# Altium schematic sheet Sheet1.SchDoc
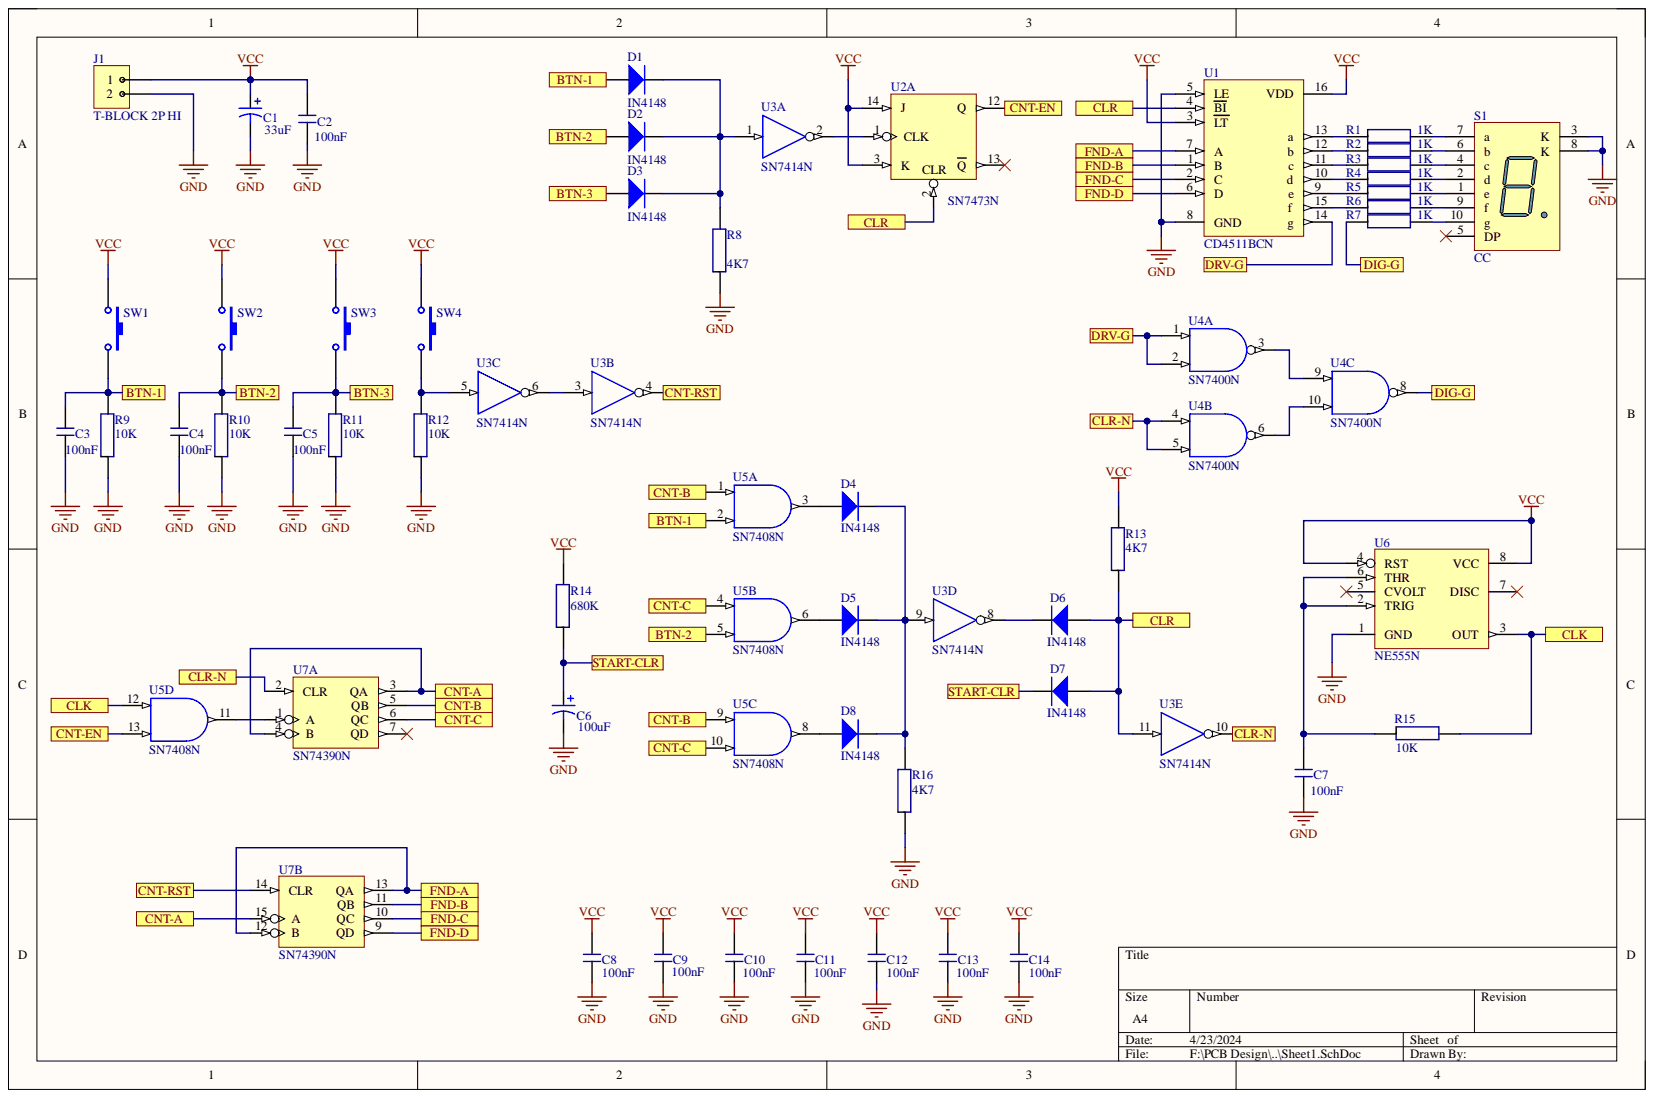
[diagram p0: Sheet1.SchDoc]

## Parts
C1  value 33uF  [cap 6mm]  (hidden comment: Capasitor Polar)
C2  value 100nF  [0805]  (hidden comment: Capasitor Non Polar)
C3  value 100nF  [0805]  (hidden comment: Capasitor Non Polar)
C4  value 100nF  [0805]  (hidden comment: Capasitor Non Polar)
C5  value 100nF  [0805]  (hidden comment: Capasitor Non Polar)
C6  value 100uF  [Elco Axial 1 - B]  (hidden comment: Capasitor Polar)
C7  value 100nF  [Cap MKM]  (hidden comment: Capasitor Non Polar)
C8  value 100nF  [Cap MKM]  (hidden comment: Capasitor Non Polar)
C9  value 100nF  [Cap MKM]  (hidden comment: Capasitor Non Polar)
C10  value 100nF  [Cap MKM]  (hidden comment: Capasitor Non Polar)
C11  value 100nF  [Cap MKM]  (hidden comment: Capasitor Non Polar)
C12  value 100nF  [Cap MKM]  (hidden comment: Capasitor Non Polar)
C13  value 100nF  [Cap MKM]  (hidden comment: Capasitor Non Polar)
C14  value 100nF  [Cap MKM]  (hidden comment: Capasitor Non Polar)
D1  IN4148  [LL34]
D2  IN4148  [LL34]
D3  IN4148  [LL34]
D4  IN4148  [LL34]
D5  IN4148  [LL34]
D6  IN4148  [LL34]
D7  IN4148  [LL34]
D8  IN4148  [LL34]
J1  T-BLOCK 2P HI  [T-BLOCK 2P HL]
R1  value 1K  [0805]  (hidden comment: Resistor)
R2  value 1K  [0805]  (hidden comment: Resistor)
R3  value 1K  [0805]  (hidden comment: Resistor)
R4  value 1K  [0805]  (hidden comment: Resistor)
R5  value 1K  [0805]  (hidden comment: Resistor)
R6  value 1K  [0805]  (hidden comment: Resistor)
R7  value 1K  [0805]  (hidden comment: Resistor)
R8  value 4K7  [0805]  (hidden comment: Resistor)
R9  value 10K  [0805]  (hidden comment: Resistor)
R10  value 10K  [0805]  (hidden comment: Resistor)
R11  value 10K  [0805]  (hidden comment: Resistor)
R12  value 10K  [0805]  (hidden comment: Resistor)
R13  value 4K7  [0805]  (hidden comment: Resistor)
R14  value 680K  [Axial 0.4]  (hidden comment: Resistor)
R15  value 10K  [0805]  (hidden comment: Resistor)
R16  value 4K7  [0805]  (hidden comment: Resistor)
S1  CC  [S7 0.56]
SW1  Tactile switch 2 P  [tactileSW2p]  (value not drawn)
SW2  Tactile switch 2 P  [tactileSW2p]  (value not drawn)
SW3  Tactile switch 2 P  [tactileSW2p]  (value not drawn)
SW4  Tactile switch 2 P  [tactileSW2p]  (value not drawn)
U1  CD4511BCN  [N16E]
U2  SN7473N  [N014C]  (part A)
U3  SN7414N  [N014]  (parts A,B,C,D,E)
U4  SN7400N  [N014]  (parts A,B,C)
U5  SN7408N  [N014]  (parts A,B,C,D)
U6  NE555N  [DIP8]
U7  SN74390N  [N016]  (parts A,B)

## Ports
BTN-1, BTN-2, BTN-3, CLK, CLR, CLR-N, CNT-A, CNT-B, CNT-C, CNT-EN, CNT-RST, DIG-G, DRV-G, FND-A, FND-B, FND-C, FND-D, START-CLR

## Nets
GND: C1.2 C2.2 C3.2 C4.2 C5.2 C6.2 C7.2 C8.2 C9.2 C10.2 C11.2 C12.2 C13.2 C14.2 J1.2 R8.2 R9.2 R10.2 R11.2 R12.2 R16.2 S1.3(K) S1.8(K) U1.5(LE) U1.8(GND) U2.11 U3.7 U4.7 U5.7 U6.1(GND) U7.8
VCC: C1.1 C2.1 C8.1 C9.1 C10.1 C11.1 C12.1 C13.1 C14.1 J1.1 R13.1 R14.1 SW1.1 SW2.1 SW3.1 SW4.1 U1.3(LT) U1.16(VDD) U2.3(K) U2.4 U2.14(J) U3.14 U4.14 U5.14 U6.4(RST) U6.8(VCC) U7.16
BTN-1: C3.1 D1.1 R9.1 SW1.2 U5.2 ; port BTN-1
BTN-2: C4.1 D2.1 R10.1 SW2.2 U5.5 ; port BTN-2
BTN-3: C5.1 D3.1 R11.1 SW3.2 ; port BTN-3
CLK: R15.1 U5.12 U6.3(OUT) ; port CLK
CLR: D6.1 D7.1 R13.2 U1.4(BI) U2.2 U3.11 ; port CLR
CLR-N: U3.10 U4.4 U4.5 U7.2(CLR) ; port CLR-N
CNT-A: U7.3(QA) U7.4(B) U7.15(A) ; port CNT-A
CNT-B: U5.1 U5.9 U7.5(QB) ; port CNT-B
CNT-C: U5.4 U5.10 U7.6(QC) ; port CNT-C
CNT-EN: U2.12(Q) U5.13 ; port CNT-EN
CNT-RST: U3.4 U7.14(CLR) ; port CNT-RST
DIG-G: R7.2 U4.8 ; port DIG-G
DRV-G: U1.14(g) U4.1 U4.2 ; port DRV-G
FND-A: U1.7(A) U7.12(B) U7.13(QA) ; port FND-A
FND-B: U1.1(B) U7.11(QB) ; port FND-B
FND-C: U1.2(C) U7.10(QC) ; port FND-C
FND-D: U1.6(D) U7.9(QD) ; port FND-D
START-CLR: C6.1 D7.2 R14.2 ; port START-CLR
NetC7_1: C7.1 R15.2 U6.2(TRIG) U6.6(THR)
NetD1_2: D1.2 D2.2 D3.2 R8.1 U3.1
NetD4_1: D4.1 U5.3
NetD4_2: D4.2 D5.2 D8.2 R16.1 U3.9
NetD5_1: D5.1 U5.6
NetD6_2: D6.2 U3.8
NetD8_1: D8.1 U5.8
NetR1_1: R1.1 S1.7(a)
NetR1_2: R1.2 U1.13(a)
NetR2_1: R2.1 S1.6(b)
NetR2_2: R2.2 U1.12(b)
NetR3_1: R3.1 S1.4(c)
NetR3_2: R3.2 U1.11(c)
NetR4_1: R4.1 S1.2(d)
NetR4_2: R4.2 U1.10(d)
NetR5_1: R5.1 S1.1(e)
NetR5_2: R5.2 U1.9(e)
NetR6_1: R6.1 S1.9(f)
NetR6_2: R6.2 U1.15(f)
NetR7_1: R7.1 S1.10(g)
NetR12_1: R12.1 SW4.2 U3.5
NetU2_1: U2.1(CLK) U3.2
NetU3_3: U3.3 U3.6
NetU4_3: U4.3 U4.9
NetU4_6: U4.6 U4.10
NetU5_11: U5.11 U7.1(A)

Not connected: S1 5(DP); U2 13(Q); U6 5(CVOLT) 7(DISC); U7 7(QD)

## Library data of the parts
D1-D8 = Diode IN40XX: Value: IN400X; Component Kind: Standard; Library Name: faridLib.IntLib
S1 = Display S7 CC: Component Kind: Standard; Library Name: faridLib.IntLib
U1 = CD4511BCN: BCD-to-7 Segment Latch/Decoder/Driver; Component Kind: Standard; ComponentLink1Description: Manufacturer Link; ComponentLink1URL: http://www.fairchildsemi.com/; ComponentLink2Description: Datasheet; ComponentLink2URL: http://www.fairchildsemi.com/ds/CD%2FCD4511BC.pdf; DatasheetVersion: Jan-1999; LatestRevisionDate: 04-Mar-2005; LatestRevisionNote: Stylized 3D Model Added.; Library Name: FSC Interface Display Driver.IntLib; PackageDescription: DIP, 16-Pin, Row Spacing 7.62 mm, Pitch 2.54 mm; PackageReference: N16E; PackageVersion: 2000; PCB3D: N16E; Published: 17-Jul-2002; Simulation: 4511; Publisher: Altium Limited
U2 = SN7473N: Dual J-K Flip-Flop with Clear; ComponentAvailability: Obsolete; Component Kind: Standard; ComponentLink1Description: Manufacturer Link; ComponentLink1URL: http://www.ti.com/; ComponentLink2Description: Datasheet; ComponentLink2URL: http://www-s.ti.com/sc/ds/sn7473.pdf; DatasheetVersion: Mar-1988; LatestRevisionDate: 04-Mar-2005; LatestRevisionNote: Stylized 3D Model Added.; Library Name: TI Logic Flip-Flop.IntLib; PackageDescription: DIP; 14 Leads; Row Spacing 7.62 mm; Pitch 2.54 mm; PackageReference: N014C; PackageVersion: Oct-1995; PCB3D: N014; Published: 8-Jun-2000; Signal Integrity: SN7473N; Publisher: Altium Limited
U3 = SN7404N: Hex Inverter; Component Kind: Standard; ComponentLink1Description: Manufacturer Link; ComponentLink1URL: http://www.ti.com/; ComponentLink2Description: Datasheet; ComponentLink2URL: http://www-s.ti.com/sc/ds/sn5404.pdf; ComponentLink3Description: Packaging Specification; ComponentLink3URL: http://focus.ti.com/lit/ml/mpdi002c/mpdi002c.pdf; DatasheetVersion: Mar-1988; LatestRevisionDate: 04-Mar-2005; LatestRevisionNote: Stylized 3D Model Added.; Library Name: TI Logic Gate 1.IntLib; PackageDescription: DIP; 14 Leads; Row Spacing 7.62 mm; Pitch 2.54 mm; PackageReference: N014; PackageVersion: Oct-1995; PCB3D: N014; Published: 8-Jun-2000; Signal Integrity: SN7404N; Publisher: Altium Limited
U4 = SN7400N: Quadruple 2-Input Positive-NAND Gate; Component Kind: Standard; ComponentLink1Description: Manufacturer Link; ComponentLink1URL: http://www.ti.com/; ComponentLink2Description: Datasheet; ComponentLink2URL: http://www-s.ti.com/sc/ds/sn5400.pdf; ComponentLink3Description: Packaging Specification; ComponentLink3URL: http://focus.ti.com/lit/ml/mpdi002c/mpdi002c.pdf; DatasheetVersion: Mar-1988; LatestRevisionDate: 04-Mar-2005; LatestRevisionNote: Stylized 3D Model Added.; Library Name: TI Logic Gate 1.IntLib; PackageDescription: DIP; 14 Leads; Row Spacing 7.62 mm; Pitch 2.54 mm; PackageReference: N014; PackageVersion: Oct-1995; PCB3D: N014; Published: 8-Jun-2000; Signal Integrity: SN7400N; Publisher: Altium Limited
U5 = SN7408N: Quadruple 2-Input Positive-AND Gate; Component Kind: Standard; ComponentLink1Description: Manufacturer Link; ComponentLink1URL: http://www.ti.com/; ComponentLink2Description: Datasheet; ComponentLink2URL: http://www-s.ti.com/sc/ds/sn54ls08.pdf; ComponentLink3Description: Packaging Specification; ComponentLink3URL: http://focus.ti.com/lit/ml/mpdi002c/mpdi002c.pdf; DatasheetVersion: Mar-1988; LatestRevisionDate: 04-Mar-2005; LatestRevisionNote: Stylized 3D Model Added.; Library Name: TI Logic Gate 1.IntLib; PackageDescription: DIP; 14 Leads; Row Spacing 7.62 mm; Pitch 2.54 mm; PackageReference: N014; PackageVersion: Oct-1995; PCB3D: N014; Published: 8-Jun-2000; Signal Integrity: SN7408N; Publisher: Altium Limited
U6 = NE555N: General-Purpose Single Bipolar Timer; Code_JEDEC: MO-001-AN; Component Kind: Standard; ComponentLink1Description: Manufacturer Link; ComponentLink1URL: http://www.st.com/; ComponentLink2Description: Datasheet; ComponentLink2URL: http://www.st.com/stonline/products/literature/ds/2182/ne555.pdf; DatasheetVersion: Jul-1998; LatestRevisionDate: 06-Jun-2005; LatestRevisionNote: Stylized 3D Model Added.; Library Name: ST Analog Timer Circuit.IntLib; PackageDescription: DIP; 8 Leads; Row Spacing 7.62 mm; Pitch 2.54 mm; PackageReference: DIP8; PCB3D: DIP8; Published: 24-Aug-2001; Publisher: Altium Limited
U7 = SN74390N: Dual 4-Bit Decade and Binary Counter; ComponentAvailability: Obsolete; Code_JEDEC: MS-001-BB; Component Kind: Standard; ComponentLink1Description: Manufacturer Link; ComponentLink1URL: http://www.ti.com/; ComponentLink2Description: Datasheet; ComponentLink2URL: http://www-s.ti.com/sc/ds/sn74393.pdf; DatasheetVersion: Mar-1988; LatestRevisionDate: 04-Mar-2005; LatestRevisionNote: Stylized 3D Model Added.; Library Name: TI Logic Counter.IntLib; PackageDescription: DIP; 16 Leads; Row Spacing 7.62 mm; Pitch 2.54 mm; PackageReference: N016; PackageVersion: Oct-1995; PCB3D: N016; Published: 8-Jun-2000; Signal Integrity: SN74390N; Publisher: Altium Limited
C1 C6 = Capasitor Polar: Component Kind: Standard; Library Name: faridLib.IntLib
C2-C5 C7-C14 = Capasitor Non Polar: Component Kind: Standard; Library Name: faridLib.IntLib
J1 = T-BLOCK 2P HI: Component Kind: Standard; Library Name: faridLib.IntLib
R1-R16 = Resistor: Component Kind: Standard; Library Name: faridLib.IntLib
SW1-SW4 = Tactile switch 2 P: Component Kind: Standard; Library Name: faridLib.IntLib
